# Altium schematic sheet 08_OTHER.SchDoc
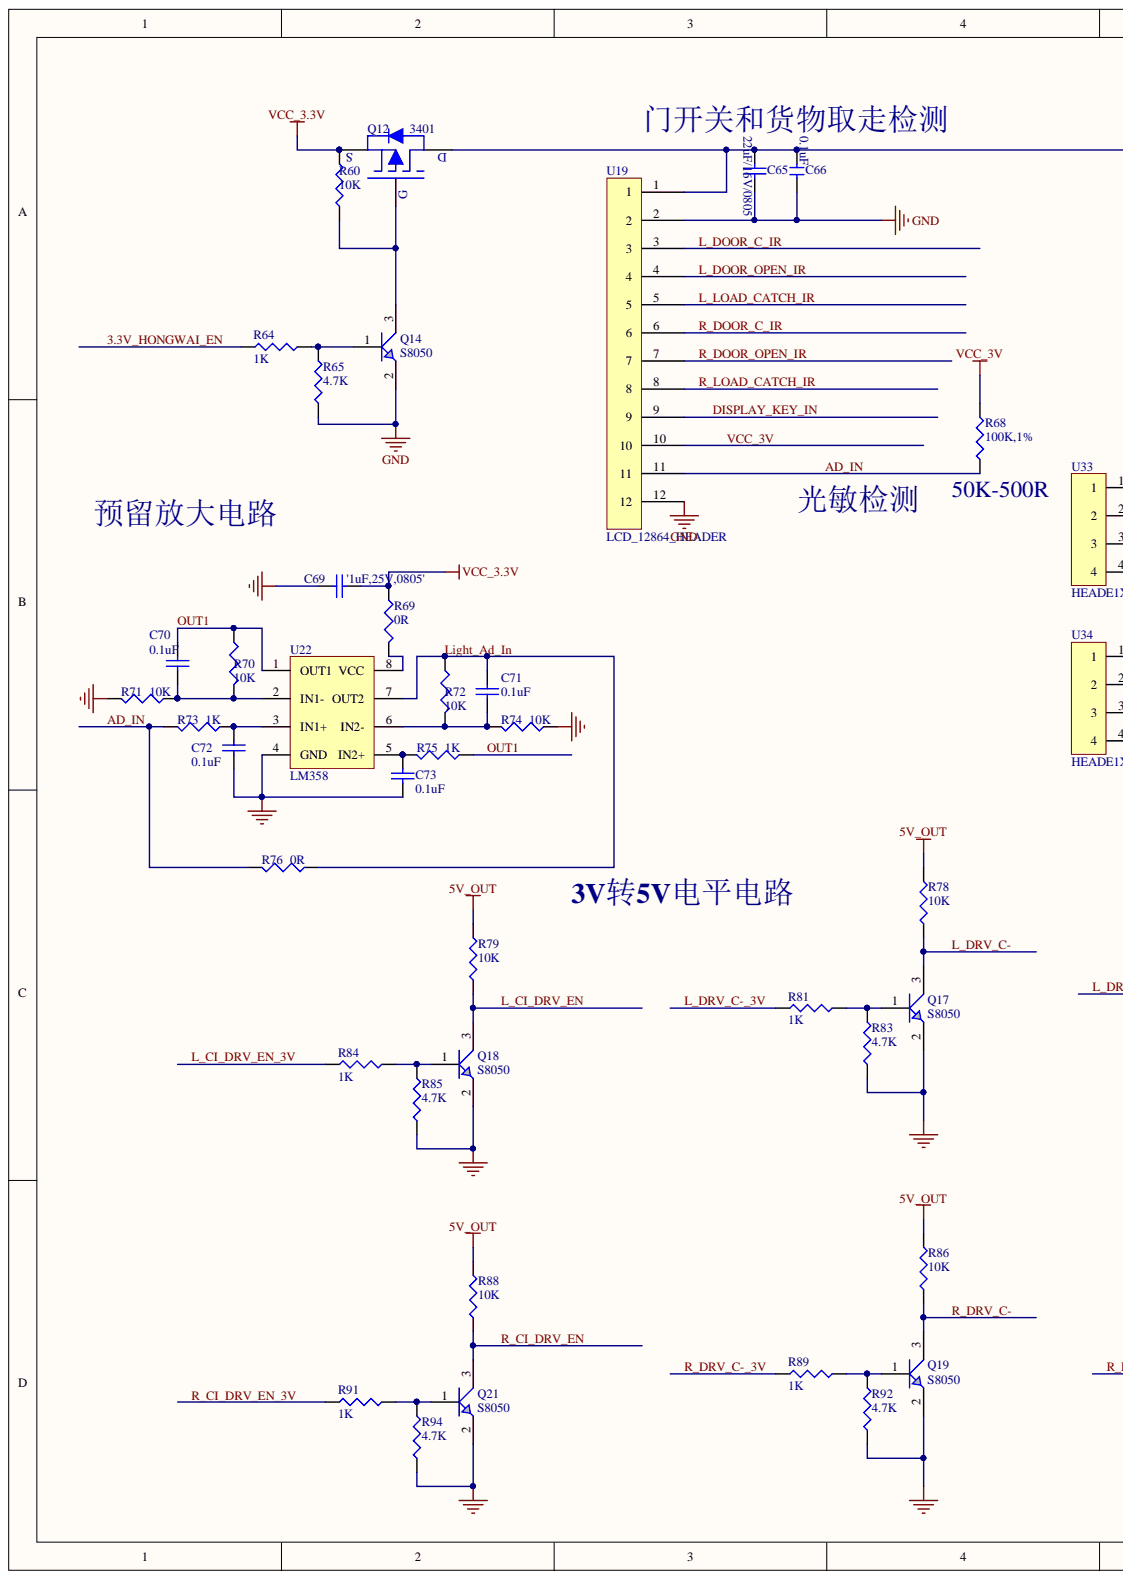
[diagram p0: 08_OTHER.SchDoc, left]
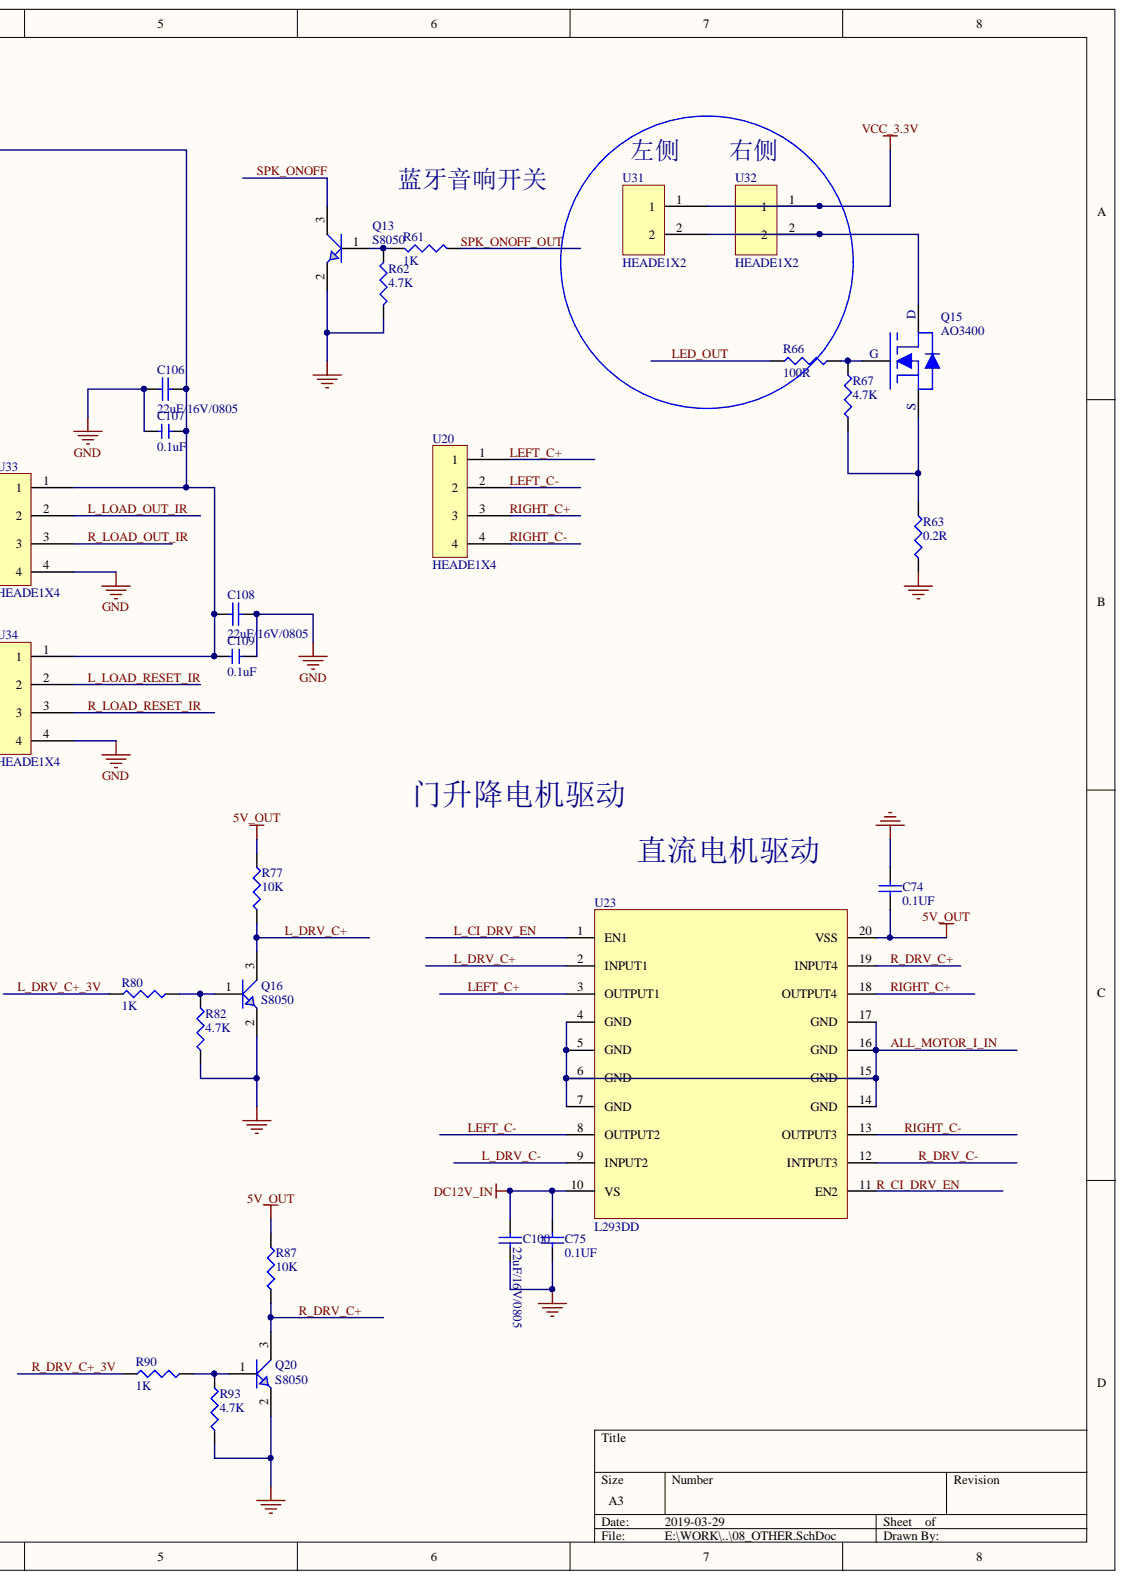
[diagram p1: 08_OTHER.SchDoc, right]

## Parts
C65  22uF/16V/0805  [0805_C]
C66  0.1uF  [0603_C]
C69  value '1uF,25V,0805'  [0805_C]  (hidden comment: 1UF)
C70  0.1uF  [0603_C]
C71  0.1uF  [0603_C]
C72  0.1UF  [0603_C]
C73  0.1UF  [0603_C]
C74  0.1uf  [0603_C]
C75  0.1uf  [0603_C]
C100  22uF/16V/0805  [1206_C]
C106  22uF/16V/0805  [0805_C]
C107  0.1uF  [0603_C]
C108  22uF/16V/0805  [0805_C]
C109  0.1uF  [0603_C]
Q12  3401  [SOT23]
Q13  S8050  [SOT23]
Q14  S8050  [SOT23]
Q15  value AO3400  [SOT23]  (hidden comment: MOS)
Q16  S8050  [SOT23]
Q17  S8050  [SOT23]
Q18  S8050  [SOT23]
Q19  S8050  [SOT23]
Q20  S8050  [SOT23]
Q21  S8050  [SOT23]
R60  10K  [0603_R]
R61  1K  [0603_R]
R62  4.7K  [0603_R]
R63  0.2R  [1206_R]
R64  1K  [0603_R]
R65  4.7K  [0603_R]
R66  100R  [0603_R]
R67  4.7K  [0603_R]
R68  100K,1%  [0603_R]
R69  0R  [0603_R]
R70  10K  [0603_R]
R71  10K  [0603_R]
R72  10K  [0603_R]
R73  1K  [0603_R]
R74  10K  [0603_R]
R75  1K  [0603_R]
R76  0R  [0603_R]
R77  10K  [0603_R]
R78  10K  [0603_R]
R79  10K  [0603_R]
R80  1K  [0603_R]
R81  1K  [0603_R]
R82  4.7K  [0603_R]
R83  4.7K  [0603_R]
R84  1K  [0603_R]
R85  4.7K  [0603_R]
R86  10K  [0603_R]
R87  10K  [0603_R]
R88  10K  [0603_R]
R89  1K  [0603_R]
R90  1K  [0603_R]
R91  1K  [0603_R]
R92  4.7K  [0603_R]
R93  4.7K  [0603_R]
R94  4.7K  [0603_R]
U19  value LCD_12864_HEADER  [HEADER1X12_1.25MM-V]  (hidden comment: IC)
U20  value HEADE1X4  [HEADER1X4_1.25mm-V]  (hidden comment: IC)
U22  LM358  [SOP8]
U23  L293DD  [SOP20]
U31  value HEADE1X2  [HEADER1X2_1.25MM-V]  (hidden comment: IC)
U32  value HEADE1X2  [HEADER1X2_1.25MM-V]  (hidden comment: IC)
U33  value HEADE1X4  [HEADER1X4_1.25mm-V]  (hidden comment: IC)
U34  value HEADE1X4  [HEADER1X4_1.25mm-V]  (hidden comment: IC)

## Nets
5V_OUT: C74.2 R77.2 R78.2 R79.2 R86.2 R87.2 R88.2 U23.20(VSS)
DC12V_IN: C75.2 C100.2 U23.10(VS)
GND: C65.1 C66.1 C69.1 C72.2 C73.2 C74.1 C75.1 C100.1 C106.1 C107.1 C108.1 C109.1 Q13.2 Q14.2 Q16.2 Q17.2 Q18.2 Q19.2 Q20.2 Q21.2 R62.1 R63.1 R65.1 R71.2 R74.1 R82.1 R83.1 R85.1 R92.1 R93.1 R94.1 U19.2 U19.12 U22.4(GND) U33.4 U34.4
VCC_3.3V: C69.2 Q12.2 R60.2 R69.1 U31.1 U32.1
3.3V_HONGWAI_EN: R64.1
AD_IN: R68.2 R73.2 R76.2 U19.11
ALL_MOTOR_I_IN: U23.4(GND) U23.5(GND) U23.6(GND) U23.7(GND) U23.14(GND) U23.15(GND) U23.16(GND) U23.17(GND)
DISPLAY_KEY_IN: U19.9
L_CI_DRV_EN: Q18.3 R79.1 U23.1(EN1)
L_CI_DRV_EN_3V: R84.1
L_DOOR_C_IR: U19.3
L_DOOR_OPEN_IR: U19.4
L_DRV_C+: Q16.3 R77.1 U23.2(INPUT1)
L_DRV_C+_3V: R80.1
L_DRV_C-: Q17.3 R78.1 U23.9(INPUT2)
L_DRV_C-_3V: R81.1
L_LOAD_CATCH_IR: U19.5
L_LOAD_OUT_IR: U33.2
L_LOAD_RESET_IR: U34.2
LED_OUT: R66.1
LEFT_C+: U20.1 U23.3(OUTPUT1)
LEFT_C-: U20.2 U23.8(OUTPUT2)
LIGHT_AD_IN: C71.1 R72.1 R76.1 U22.7(OUT2)
OUT1: C70.1 R70.1 R75.1 U22.1(OUT1)
R_CI_DRV_EN: Q21.3 R88.1 U23.11(EN2)
R_CI_DRV_EN_3V: R91.1
R_DOOR_C_IR: U19.6
R_DOOR_OPEN_IR: U19.7
R_DRV_C+: Q20.3 R87.1 U23.19(INPUT4)
R_DRV_C+_3V: R90.1
R_DRV_C-: Q19.3 R86.1 U23.12(INTPUT3)
R_DRV_C-_3V: R89.1
R_LOAD_CATCH_IR: U19.8
R_LOAD_OUT_IR: U33.3
R_LOAD_RESET_IR: U34.3
RIGHT_C+: U20.3 U23.18(OUTPUT4)
RIGHT_C-: U20.4 U23.13(OUTPUT3)
SPK_ONOFF: Q13.3
SPK_ONOFF_OUT: R61.1
VCC_3V: R68.1 U19.10
NetC65_2: C65.2 C66.2 C106.2 C107.2 C108.2 C109.2 Q12.3 U19.1 U33.1 U34.1
NetC70_2: C70.2 R70.2 R71.1 U22.2(IN1-)
NetC71_2: C71.2 R72.2 R74.2 U22.6(IN2-)
NetC72_1: C72.1 R73.1 U22.3(IN1+)
NetC73_1: C73.1 R75.2 U22.5(IN2+)
NetQ12_1: Q12.1 Q14.3 R60.1
NetQ13_1: Q13.1 R61.2 R62.2
NetQ14_1: Q14.1 R64.2 R65.2
NetQ15_1: Q15.1 R66.2 R67.2
NetQ15_2: Q15.2 R63.2 R67.1
NetQ15_3: Q15.3 U31.2 U32.2
NetQ16_1: Q16.1 R80.2 R82.2
NetQ17_1: Q17.1 R81.2 R83.2
NetQ18_1: Q18.1 R84.2 R85.2
NetQ19_1: Q19.1 R89.2 R92.2
NetQ20_1: Q20.1 R90.2 R93.2
NetQ21_1: Q21.1 R91.2 R94.2
NetR69_2: R69.2 U22.8(VCC)

## Library data of the parts
C65 C69-C75 C100 C106 C108 = Cap Semi: Capacitor (Semiconductor SIM Model); Component Kind: Standard; LatestRevisionDate: 17-Jul-2002; LatestRevisionNote: Re-released for DXP Platform.; PackageReference: C1206; Published: 8-Jun-2000; Publisher: Altium Limited
  C65 C100 C106 C108: Value: 22uF/16V/0805
  C70-C73: Value: 0.1uF
  C74 C75: Value: 0.1UF
C66 C107 C109 = Cap: Component Kind: Standard; Library Name: Celestial.SchLib; Type: Cap
Q12 = PMOS_SOT23: Type: MOS; Component Kind: Standard; Library Name: Celestial.SchLib
Q15 = NMOS_SOT23: Component Kind: Standard; Library Name: Jackson SCH.LIB
R60-R68 R77-R94 = Res: Component Kind: Standard; Type: Res
  R60-R67 R77-R94: Library Name: Celestial.SchLib
  R68: Library Name: Celestial SCH.LIB
R69-R76 = Res3: Resistor; Component Kind: Standard; LatestRevisionDate: 17-Jul-2002; LatestRevisionNote: Re-released for DXP Platform.; Published: 8-Jun-2000; Publisher: Altium Limited
  R69 R76: Value: 0R
  R70-R72 R74: Value: 10K
  R73 R75: Value: 1K
U19 = HEADE1X12: Component Kind: Standard; Library Name: Jackson SCH.LIB
U20 U33 U34 = HEADE1X4: Component Kind: Standard; Library Name: Jackson SCH.LIB
U31 U32 = HEADE1X2: Component Kind: Standard; Library Name: Jackson SCH.LIB
Q13 Q14 Q16-Q21 = S8050: Component Kind: Standard; Value: S8050; Library Name: Jackson SCH.LIB
U22 = LM358: Component Kind: Standard; Library Name: Jackson.LIB
U23 = L293DD: Component Kind: Standard; Value: L293DD; Library Name: Jackson SCH.LIB
